# Altium schematic sheet Sheet1.SchDoc(Sheet1)
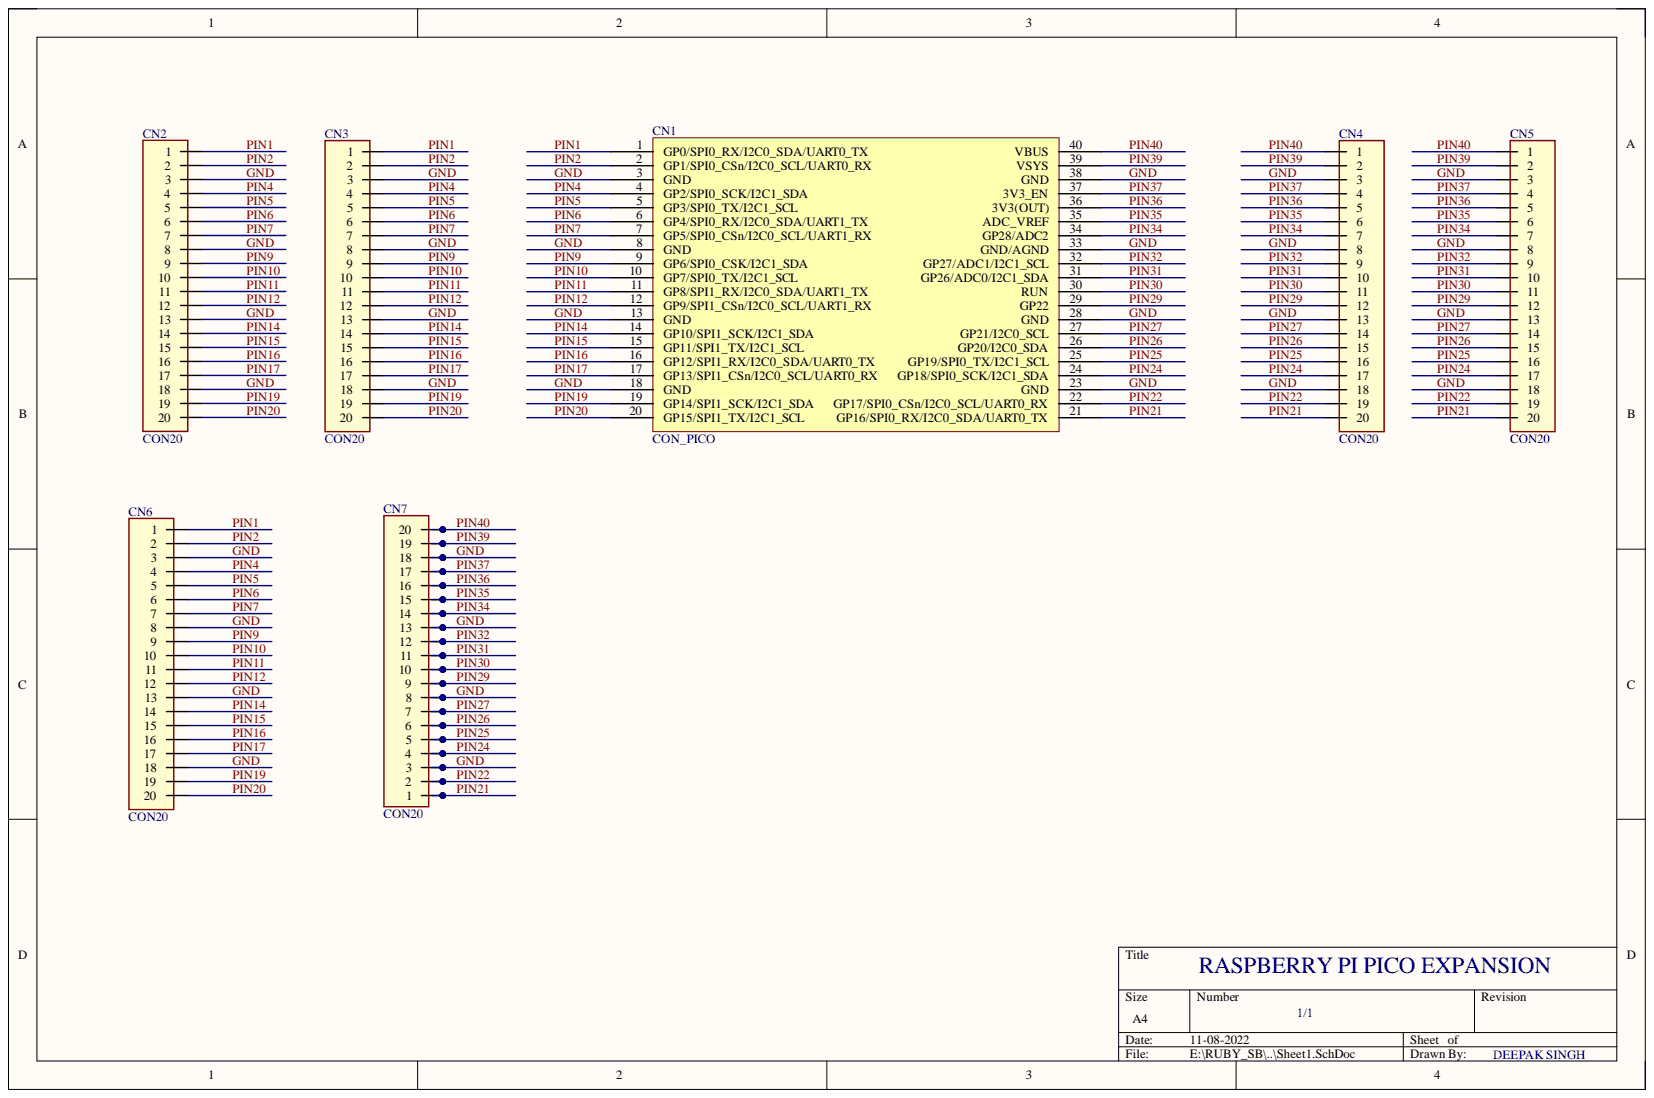
[diagram p0: Sheet1.SchDoc]

## Parts
CN1  CON_PICO  [CON40_PICO]
CN2  CON20  [CON20_2p5mm]
CN3  CON20  [CON20_2p5mm]
CN4  CON20  [CON20_2p5mm]
CN5  CON20  [CON20_2p5mm]
CN6  CON20  [20p screw term3.5mm]
CN7  CON20  [20p screw term3.5mm]

## Nets
GND: CN1.3(GND) CN1.8(GND) CN1.13(GND) CN1.18(GND) CN1.23(GND) CN1.28(GND) CN1.33(GND/AGND) CN1.38(GND) CN2.3 CN2.8 CN2.13 CN2.18 CN3.3 CN3.8 CN3.13 CN3.18 CN4.3 CN4.8 CN4.13 CN4.18 CN5.3 CN5.8 CN5.13 CN5.18 CN6.3 CN6.8 CN6.13 CN6.18 CN7.3 CN7.8 CN7.13 CN7.18
PIN1: CN1.1(GP0/SPI0_RX/I2C0_SDA/UART0_TX) CN2.1 CN3.1 CN6.1
PIN2: CN1.2(GP1/SPI0_CSn/I2C0_SCL/UART0_RX) CN2.2 CN3.2 CN6.2
PIN4: CN1.4(GP2/SPI0_SCK/I2C1_SDA) CN2.4 CN3.4 CN6.4
PIN5: CN1.5(GP3/SPI0_TX/I2C1_SCL) CN2.5 CN3.5 CN6.5
PIN6: CN1.6(GP4/SPI0_RX/I2C0_SDA/UART1_TX) CN2.6 CN3.6 CN6.6
PIN7: CN1.7(GP5/SPI0_CSn/I2C0_SCL/UART1_RX) CN2.7 CN3.7 CN6.7
PIN9: CN1.9(GP6/SPI0_CSK/I2C1_SDA) CN2.9 CN3.9 CN6.9
PIN10: CN1.10(GP7/SPI0_TX/I2C1_SCL) CN2.10 CN3.10 CN6.10
PIN11: CN1.11(GP8/SPI1_RX/I2C0_SDA/UART1_TX) CN2.11 CN3.11 CN6.11
PIN12: CN1.12(GP9/SPI1_CSn/I2C0_SCL/UART1_RX) CN2.12 CN3.12 CN6.12
PIN14: CN1.14(GP10/SPI1_SCK/I2C1_SDA) CN2.14 CN3.14 CN6.14
PIN15: CN1.15(GP11/SPI1_TX/I2C1_SCL) CN2.15 CN3.15 CN6.15
PIN16: CN1.16(GP12/SPI1_RX/I2C0_SDA/UART0_TX) CN2.16 CN3.16 CN6.16
PIN17: CN1.17(GP13/SPI1_CSn/I2C0_SCL/UART0_RX) CN2.17 CN3.17 CN6.17
PIN19: CN1.19(GP14/SPI1_SCK/I2C1_SDA) CN2.19 CN3.19 CN6.19
PIN20: CN1.20(GP15/SPI1_TX/I2C1_SCL) CN2.20 CN3.20 CN6.20
PIN21: CN1.21(GP16/SPI0_RX/I2C0_SDA/UART0_TX) CN4.20 CN5.20 CN7.1
PIN22: CN1.22(GP17/SPI0_CSn/I2C0_SCL/UART0_RX) CN4.19 CN5.19 CN7.2
PIN24: CN1.24(GP18/SPI0_SCK/I2C1_SDA) CN4.17 CN5.17 CN7.4
PIN25: CN1.25(GP19/SPI0_TX/I2C1_SCL) CN4.16 CN5.16 CN7.5
PIN26: CN1.26(GP20/I2C0_SDA) CN4.15 CN5.15 CN7.6
PIN27: CN1.27(GP21/I2C0_SCL) CN4.14 CN5.14 CN7.7
PIN29: CN1.29(GP22) CN4.12 CN5.12 CN7.9
PIN30: CN1.30(RUN) CN4.11 CN5.11 CN7.10
PIN31: CN1.31(GP26/ADC0/I2C1_SDA) CN4.10 CN5.10 CN7.11
PIN32: CN1.32(GP27/ADC1/I2C1_SCL) CN4.9 CN5.9 CN7.12
PIN34: CN1.34(GP28/ADC2) CN4.7 CN5.7 CN7.14
PIN35: CN1.35(ADC_VREF) CN4.6 CN5.6 CN7.15
PIN36: CN1.36(3V3(OUT)) CN4.5 CN5.5 CN7.16
PIN37: CN1.37(3V3_EN) CN4.4 CN5.4 CN7.17
PIN39: CN1.39(VSYS) CN4.2 CN5.2 CN7.19
PIN40: CN1.40(VBUS) CN4.1 CN5.1 CN7.20

## Library data of the parts
CN1 = CON40_PICO: Component Kind: Standard; Library Name: dxp_pasl_lib.IntLib
CN2-CN7 = CON20: Component Kind: Standard; Library Name: dxp_pasl_lib.SCHLIB
